# Altium schematic sheet ZYNQ_SD.SchDoc(ZYNQ_SD)
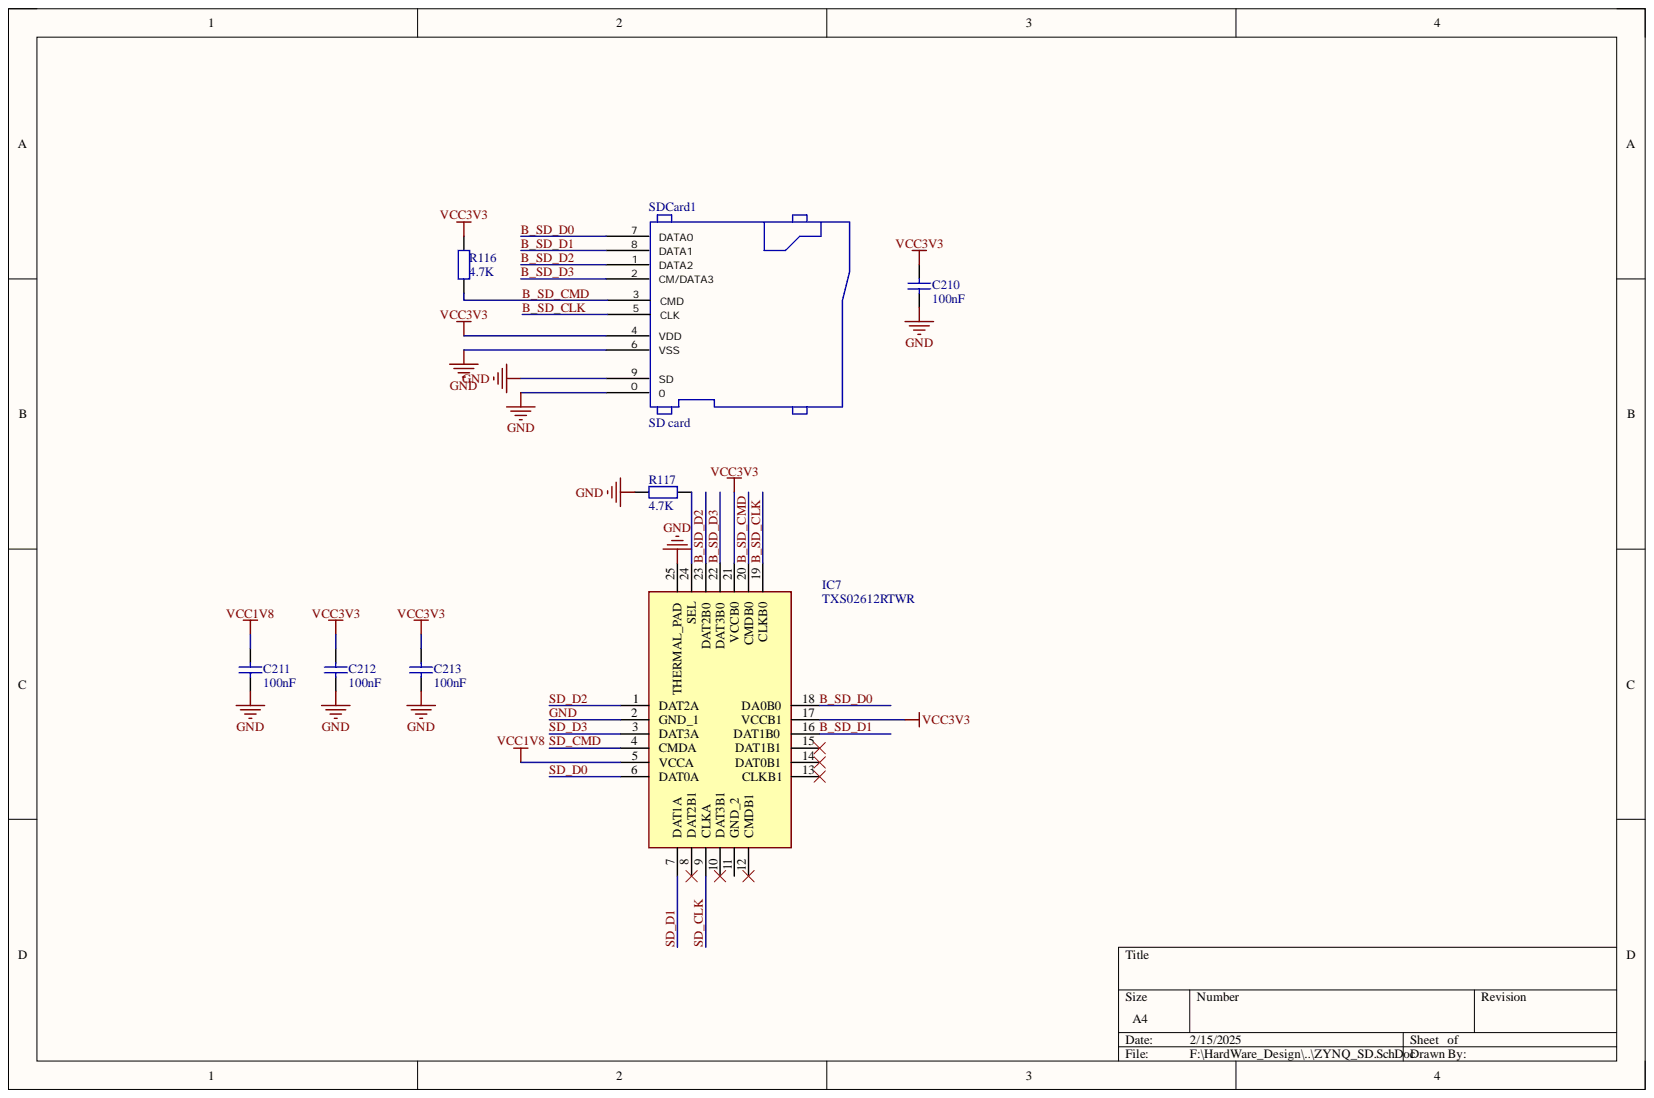
[diagram p0: ZYNQ_SD.SchDoc]

## Parts
C210  100nF  [C 0402_L]
C211  100nF  [C 0402_L]
C212  100nF  [C 0402_L]
C213  100nF  [C 0402_L]
IC7  TXS02612RTWR  [QFN50P400X400X80-25N-D]
R116  4.7K  [R 0402_L]
R117  4.7K  [R 0402_L]
SDCard1  SD card  [SD_CARD]

## Nets
VCC1V8: C211.2 IC7.5(VCCA)
VCC3V3: C210.2 C212.2 C213.2 IC7.17(VCCB1) IC7.21(VCCB0) R116.2 SDCard1.4(VDD)
B_SD_CLK: IC7.19(CLKB0) SDCard1.5(CLK)
B_SD_CMD: IC7.20(CMDB0) R116.1 SDCard1.3(CMD)
B_SD_D0: IC7.18(DA0B0) SDCard1.7(DATA0)
B_SD_D1: IC7.16(DAT1B0) SDCard1.8(DATA1)
B_SD_D2: IC7.23(DAT2B0) SDCard1.1(DATA2)
B_SD_D3: IC7.22(DAT3B0) SDCard1.2(CM/DATA3)
GND: C210.1 C211.1 C212.1 C213.1 IC7.2(GND_1) IC7.25(THERMAL_PAD) R117.2 SDCard1.0 SDCard1.6(VSS) SDCard1.9
SD_CLK: IC7.9(CLKA)
SD_CMD: IC7.4(CMDA)
SD_D0: IC7.6(DAT0A)
SD_D1: IC7.7(DAT1A)
SD_D2: IC7.1(DAT2A)
SD_D3: IC7.3(DAT3A)
NetIC7_24: IC7.24(SEL) R117.1

Not connected: IC7 8(DAT2B1) 10(DAT3B1) 11(GND_2) 12(CMDB1) 13(CLKB1) 14(DAT0B1) 15(DAT1B1)

## Library data of the parts
C210-C213 = Cap: 贴片电容; Component Kind: Standard; Library Name: A_Schlib.SchLib; value: 100nF
IC7 = TXS02612RTWR: Integrated Circuit; Manufacturer_Name: Texas Instruments; Arrow Part Number: TXS02612RTWR; Arrow Price/Stock: https://www.arrow.com/en/products/txs02612rtwr/texas-instruments?region=nac; Component Kind: Standard; Datasheet Link: http://www.ti.com/lit/gpn/txs02612; Height: 0.8mm; Library Name: SamacSys.SchLib; Manufacturer_Part_Number: TXS02612RTWR; Mouser Part Number: 595-TXS02612RTWR; Mouser Price/Stock: https://www.mouser.co.uk/ProductDetail/Texas-Instruments/TXS02612RTWR?qs=dqESbKqT1jaNFKC1R4M7hw%3D%3D
R116 R117 = Res: 通用; Component Kind: Standard; Library Name: A_Schlib.SchLib; value: 4.7K
SDCard1 = SD card: 通用; Component Kind: Standard; Library Name: A_Schlib.SchLib
